# Altium schematic sheet Sheet1.SchDoc
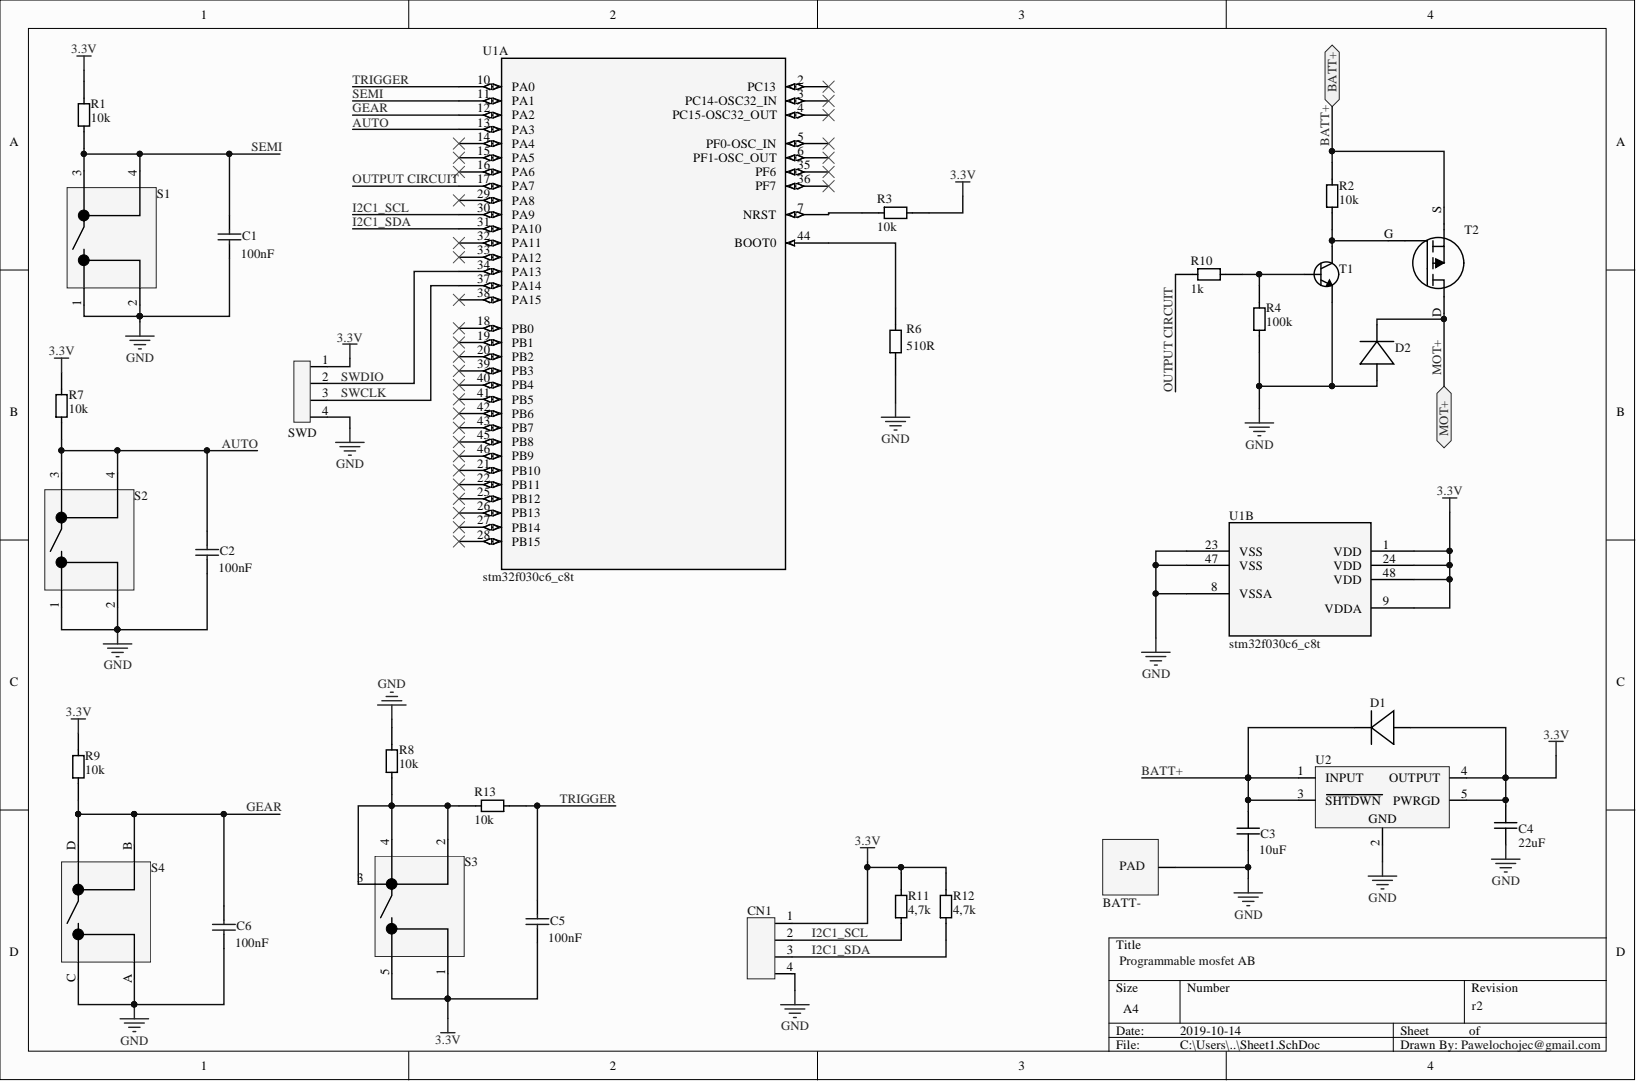
[diagram p0: Sheet1.SchDoc]

## Parts
C1  value 100nF  [C1206]  (hidden comment: Cap Semi)
C2  value 100nF  [C1206]  (hidden comment: Cap Semi)
C3  Capacitance: 10uF  [0805]  (hidden comment: CL21A106KOQNNNE)
C4  Capacitance: 22uF  [0805]  (hidden comment: CL21A226MQQNNNG)
C5  value 100nF  [C1206]  (hidden comment: Cap Semi)
C6  value 100nF  [C1206]  (hidden comment: Cap Semi)
CN1  501568-0407  [Molex 4x1]  (value not drawn)
CN3  BATT-  [PAD_1,5x0,8]
D1  TS4148RYG  [0805 diode]  (value not drawn)
D2  TS4148RYG  [0805 diode]  (value not drawn)
R1  value 10k  [PCBComponent_1]  (hidden comment: Resistor 0805)
R2  value 10k  [PCBComponent_1]  (hidden comment: Resistor 0805)
R3  value 10k  [PCBComponent_1]  (hidden comment: Resistor 0805)
R4  value 100k  [PCBComponent_1]  (hidden comment: Resistor 0805)
R6  value 510R  [PCBComponent_1]  (hidden comment: Resistor 0805)
R7  value 10k  [PCBComponent_1]  (hidden comment: Resistor 0805)
R8  value 10k  [PCBComponent_1]  (hidden comment: Resistor 0805)
R9  value 10k  [PCBComponent_1]  (hidden comment: Resistor 0805)
R10  value 1k  [PCBComponent_1]  (hidden comment: Resistor 0805)
R11  value 4,7k  [PCBComponent_1]  (hidden comment: Resistor 0805)
R12  value 4,7k  [PCBComponent_1]  (hidden comment: Resistor 0805)
R13  value 10k  [PCBComponent_1]  (hidden comment: Resistor 0805)
S1  HDT0004  [HDT0004]  (value not drawn)
S2  HDT0004  [HDT0004]  (value not drawn)
S3  KMS221GPLFS  [KMS221GLFS]  (value not drawn)
S4  HDP001L  [HDP001L]  (value not drawn)
SWD  3x1 pinout 1,27mm  [4x1 pinout 1,27mm]  (value not drawn)
T1  BC817W,115  [BC817W.115]  (value not drawn)
T2  PowerFLAT 5x6 P-CH Transistor  [PowerFLAT 5x6]  (value not drawn)
U1  stm32f030c6_c8t  [LQFP48-7x7mm]  (parts A,B)
U2  MCP1755T-3302E/OT  [SOT 23-5, AP2127K-3.3TRG1]  (value not drawn)

## Ports
BATT+, MOT+

## Nets
3.3V: C4.1 C5.1 CN1.1 D1.A R1.2 R3.2 R7.2 R9.2 R11.2 R12.2 S3.1 S3.5 SWD.1 U1.1(VDD) U1.9(VDDA) U1.24(VDD) U1.48(VDD) U2.4(OUTPUT) U2.5(PWRGD)
GND: C1.1 C2.1 C3.2 C4.2 C6.1 CN1.4 CN3.1 D2.A R4.1 R6.1 R8.2 S1.1 S1.2 S2.1 S2.2 S4.A S4.C SWD.4 T1.2 U1.8(VSSA) U1.23(VSS) U1.47(VSS) U2.2
AUTO: C2.2 R7.1 S2.3 S2.4 U1.13(PA3)
BATT+: C3.1 D1.K R2.2 T2.S U2.1(INPUT) U2.3(SHTDWN) ; port BATT+
GEAR: C6.2 R9.1 S4.B S4.D U1.12(PA2)
I2C1_SCL: CN1.2 R11.1 U1.30(PA9)
I2C1_SDA: CN1.3 R12.1 U1.31(PA10)
MOT+: D2.K T2.D ; port MOT+
OUTPUT CIRCUIT: R10.1 U1.17(PA7)
SEMI: C1.2 R1.1 S1.3 S1.4 U1.11(PA1)
SWCLK: SWD.3 U1.37(PA14)
SWDIO: SWD.2 U1.34(PA13)
TRIGGER: C5.2 R13.1 U1.10(PA0)
NetR2_1: R2.1 T1.3 T2.G
NetR3_1: R3.1 U1.7(NRST)
NetR4_2: R4.2 R10.2 T1.1
NetR6_2: R6.2 U1.44(BOOT0)
NetR8_1: R8.1 R13.2 S3.2 S3.3 S3.4

Not connected: U1 2(PC13) 3(PC14-OSC32_IN) 4(PC15-OSC32_OUT) 5(PF0-OSC_IN) 6(PF1-OSC_OUT) 14(PA4) 15(PA5) 16(PA6) 18(PB0) 19(PB1) 20(PB2) 21(PB10) 22(PB11) 25(PB12) 26(PB13) 27(PB14) 28(PB15) 29(PA8) 32(PA11) 33(PA12) 35(PF6) 36(PF7) 38(PA15) 39(PB3) 40(PB4) 41(PB5) 42(PB6) 43(PB7) 45(PB8) 46(PB9)

## Library data of the parts
C1 C2 C5 C6 = Cap Semi: Capacitor (Semiconductor SIM Model); Component Kind: Standard; LatestRevisionDate: 29-May-2009; LatestRevisionNote: IPC-7351 Footprint Added.; Library Name: Miscellaneous Devices.IntLib; PackageDescription: Chip Capacitor; PackageReference: C1206; Published: 8-Jun-2000; Publisher: Altium Limited; Signal Integrity: Cap Semi; Simulation: CAP
C3 = CL21A106KOQNNNE: Cap Ceramic 10uF 16V X5R 10% SMD 0805 85C T/R; Manufacturer: Samsung; Dielectric: X7R; Case/Package: 0805; Case Code (Imperial): 0805; Case Code (Metric): 2012; Component Kind: Standard; ComponentLink1Description: http://www.samsungsem.com/kr/support/product-search/mlcc/CL21A106KOQNNNE.jsp?src-supplier=Digi-Key; ComponentLink1URL: http://www.samsungsem.com/kr/support/product-search/mlcc/CL21A106KOQNNNE.jsp?src-supplier=Digi-Key; ComponentLink2Description: http://www1.futureelectronics.com/doc/SAMSUNG%20PASSIVE/CL05B104KQ5NNNC.pdf?src-supplier=Future+Electronics; ComponentLink2URL: http://www1.futureelectronics.com/doc/SAMSUNG%20PASSIVE/CL05B104KQ5NNNC.pdf?src-supplier=Future+Electronics; ComponentLink3Description: https://www.verical.com/server-webapp/html/getDatasheet?partID=358435&src-supplier=Verical; ComponentLink3URL: https://www.verical.com/server-webapp/html/getDatasheet?partID=358435&src-supplier=Verical; Depth: 1.25mm; Height: 1.25mm; Lead Free: Lead Free; Length: 2mm; Library Name: mosfet_STM32.SchLib; Manufacturer Part Number: CL21A106KOQNNNE; Max Operating Temperature: 85°C; Min Operating Temperature: -55°C; Mount: Surface Mount; Package Quantity: 1; Packaging: Tape and Reel; Pricing 1: 100=0.02993, 300=0.02117, 1000=0.01541, 2000=0.01308, 10000=0.01253 (USD); Radiation Hardening: No; Stock 1: 80000; Supplier 1: TME; Supplier Part Number 1: CL21A106KOQNNNE; Thickness: 0.055inch; Tolerance: 10%; Voltage: 16V; Voltage Rating: 16V; Voltage Rating (DC): 16V; Width: 0.049inch
C4 = CL21A226MQQNNNG: Cap Ceramic 22uF 6.3V X5R 20% SMD 0805 85C Embossed T/R; Manufacturer: Samsung; Case/Package: 0805; Case Code (Imperial): 0805; Case Code (Metric): 2012; Component Kind: Standard; ComponentLink1Description: http://www.samsungsem.com/kr/support/product-search/mlcc/CL21A226MQQNNNG.jsp?src-supplier=Digi-Key; ComponentLink1URL: http://www.samsungsem.com/kr/support/product-search/mlcc/CL21A226MQQNNNG.jsp?src-supplier=Digi-Key; ComponentLink2Description: https://produktinfo.conrad.com/datenblaetter/450000-474999/454647-da-01-en-VS_KONDENSATOR_0805.pdf?src-supplier=Conrad; ComponentLink2URL: https://produktinfo.conrad.com/datenblaetter/450000-474999/454647-da-01-en-VS_KONDENSATOR_0805.pdf?src-supplier=Conrad; Depth: 1.25mm; Dielectric: X5R; Height: 1.25mm; Length: 2mm; Library Name: mosfet_STM32.SchLib; Manufacturer Part Number: CL21A226MQQNNNG; Material: Ceramic; Max Operating Temperature: 85°C; Min Operating Temperature: -55°C; Mount: Surface Mount; Package Quantity: 3000; Packaging: Tape and Reel; Pricing 1: 100=0.03725, 300=0.0296, 1000=0.02317, 3000=0.01829, 12000=0.0174 (USD); Radiation Hardening: No; Stock 1: 38100; Supplier 1: TME; Supplier Part Number 1: CL21A226MQQNNNG; Thickness: 0.055inch; Tolerance: 20%; Voltage: 6.3V; Voltage Rating: 6.3V; Width: 0.049inch
CN1 = 501568-0407: Conn Shrouded Header HDR 4 POS 1mm Solder RA SMD Pico-Clasp™ T/R; Manufacturer: Molex; Connector Type: Male Pin; Current Rating: 1A; Gender: Male; Glow Wire Compliant: Non-compliant; Max Voltage Rating (AC): 50V; Max Voltage Rating (DC): 5.05kV; Number of Circuits: 4; Color: Natural; Component Kind: Standard; ComponentLink1Description: http://www.molex.com/webdocs/datasheets/pdf/en-us/5015680407_PCB_HEADERS.pdf?src-supplier=Mouser; ComponentLink1URL: http://www.molex.com/webdocs/datasheets/pdf/en-us/5015680407_PCB_HEADERS.pdf?src-supplier=Mouser; ComponentLink2Description: http://www.onlinecomponents.com/productFiles/mf-MOL/940865015680407_pcb_headers.pdf?src-supplier=Onlinecomponents.com; ComponentLink2URL: http://www.onlinecomponents.com/productFiles/mf-MOL/940865015680407_pcb_headers.pdf?src-supplier=Onlinecomponents.com; ComponentLink3Description: http://www.molex.com/webdocs/datasheets/pdf/en-us/5015680407_PCB_HEADERS.pdf?src-supplier=Avnet; ComponentLink3URL: http://www.molex.com/webdocs/datasheets/pdf/en-us/5015680407_PCB_HEADERS.pdf?src-supplier=Avnet; ComponentLink4Description: https://www.molex.com/pdm_docs/ps/PS-501568-001.pdf?src-supplier=Digi-Key; ComponentLink4URL: https://www.molex.com/pdm_docs/ps/PS-501568-001.pdf?src-supplier=Digi-Key; ComponentLink5Description: http://uk.rs-online.com/webdocs/13c5/0900766b813c54a0.pdf?src-supplier=RSComponents; ComponentLink5URL: http://uk.rs-online.com/webdocs/13c5/0900766b813c54a0.pdf?src-supplier=RSComponents; ComponentLink6Description: http://www.molex.com/webdocs/datasheets/pdf/en-us/5015680407_PCB_HEADERS.pdf?src-supplier=Avnet+Europe; ComponentLink6URL: http://www.molex.com/webdocs/datasheets/pdf/en-us/5015680407_PCB_HEADERS.pdf?src-supplier=Avnet+Europe; Contact Material: Bronze,Brass; Contact Plating: Tin; Depth: 5.35mm; Fastening Type: Detent; Housing Color: Natural; Housing Material: Nylon; Lead Free: Lead Free; Length: 6mm; Library Name: mosfet_STM32.SchLib; Manufacturer Part Number: 501568-0407; Max Operating Temperature: 85°C; Min Operating Temperature: -40°C; Mount: Surface Mount; Number of Contacts: 4; Number of Positions: 4; Number of Rows: 1; Orientation: Right Angle; Package Quantity: 1700; Packaging: Tape and Reel; Pitch: 1mm; Pricing 1: 2=0.59, 20=0.53, 100=0.48, 500=0.42 (USD); Radiation Hardening: No; REACH SVHC: Unknown; Stock 1: 1606; Supplier 1: TME; Supplier Part Number 1: MX-501568-0407; Termination: Solder; Voltage Rating: 50V; Voltage Rating (AC): 50V
CN3 = PAD_1,5x0,8: Component Kind: Standard; Library Name: mosfet_STM32.SchLib
D1 D2 = TS4148RYG: Diode Small Signal Switching 75V 0.15A 2-Pin Case 0805 T/R; Manufacturer: Taiwan Semiconductor; Element Configuration: Single; Forward Voltage: 1V; Max Forward Surge Current (Ifsm): 2A; Reverse Recovery Time: 4ns; Case/Package: SMD/SMT; Component Kind: Standard; ComponentLink1Description: http://www.rapidonline.com/pdf/47-1004.pdf?src-supplier=Rapid+Electronics; ComponentLink1URL: http://www.rapidonline.com/pdf/47-1004.pdf?src-supplier=Rapid+Electronics; ComponentLink2Description: https://www.verical.com/server-webapp/html/getDatasheet?partID=461722&src-supplier=Verical; ComponentLink2URL: https://www.verical.com/server-webapp/html/getDatasheet?partID=461722&src-supplier=Verical; Library Name: mosfet_STM32.SchLib; Manufacturer Part Number: TS4148RYG; Max Operating Temperature: 175°C; Max Repetitive Reverse Voltage (Vrrm): 75V; Min Operating Temperature: -65°C; Mount: Surface Mount; Number of Pins: 2; Packaging: Tape and Reel; Peak Non-Repetitive Surge Current: 2A; Pricing 1: 25=0.0275, 100=0.018, 500=0.0144, 1000=0.0129, 5000=0.012 (USD); Radiation Hardening: No; Stock 1: 145631; Supplier 1: TME; Supplier Part Number 1: TS4148-RYG
R1-R4 R6-R13 = 0805S8F1000T5E: Resistor: thick film; SMD; 0805; 100O; 0.125W; ±1%; -55÷125°C; Manufacturer: Royal Electronic Factory; Component Kind: Standard; Library Name: Schlib1.SchLib; Manufacturer Part Number: 0805S8F1000T5E; Pricing 1: 5000=0.00537, 50000=0.00395, 200000=0.0037 (USD); Stock 1: 100000; Supplier 1: TME; Supplier Part Number 1: 0805S8F1000T5E
S1 S2 = HDT0004: SWITCH DETECT MICRO SPST SMD 5V; Manufacturer: ITT C&K; Actuator Length: 1.5mm; Actuator Type: Lever; Contact Current Rating: 1mA; Contact Resistance: 500mR; Electrical Life: 100000Cycles; Operating Force: 0.34N; Operating Position: 0.138inch; Overtravel: 0.039inch; Pretravel: 0.012inch; Switch Function: Off-Mom; Case/Package: SMD/SMT; Component Kind: Standard; ComponentLink1Description: http://www.farnell.com/datasheets/1707717.pdf?src-supplier=Element14; ComponentLink1URL: http://www.farnell.com/datasheets/1707717.pdf?src-supplier=Element14; ComponentLink2Description: http://www.ck-components.com/index.php?module=media&action=Display&cmpref=15147&lang=en&width=&height=&format=&alt=&src-supplier=Digi-Key; ComponentLink2URL: http://www.ck-components.com/index.php?module=media&action=Display&cmpref=15147&lang=en&width=&height=&format=&alt=&src-supplier=Digi-Key; ComponentLink3Description: https://www.ckswitches.com/media/1307/hdt.pdf?src-supplier=Digi-Key; ComponentLink3URL: https://www.ckswitches.com/media/1307/hdt.pdf?src-supplier=Digi-Key; Contact Material: Bronze,Silver; Current Rating: 1mA; Height: 2.3mm; Lead Free: Lead Free; Library Name: mosfet_STM32.SchLib; Manufacturer Part Number: HDT0004; Max Operating Temperature: 85°C; Max Voltage Rating (DC): 5V; Mechanical Life: 100000Cycles; Min Operating Temperature: -40°C; Mount: Surface Mount; Number of Terminals: 4; Orientation: Straight; Package Quantity: 1; Packaging: Tape and Reel; Pricing 1: 1=0.9131, 5=0.8114, 20=0.7611, 100=0.7108, 500=0.6851 (USD); REACH SVHC: No SVHC; Stock 1: 2654; Supplier 1: TME; Supplier Part Number 1: HDT0004; Termination: SMD/SMT; Throw Configuration: SPST; Voltage Rating (DC): 5V; Width: 3.8mm
S3 = KMS221GPLFS: SWITCH TACTILE SPST-NO 0.05A 50V; Manufacturer: ITT C&K; Actuator Length: 0.75mm; Contact Current Rating: 50mA; Illumination Type: Non-Illuminated; Max Voltage Rating (DC): 32V; Mechanical Life: 100000Cycles; Operating Force: 2N; Power Rating: 1W; Ratings: IP40; Switch Function: Off-Mom; Switch Travel: 0.25mm; Throw Configuration: SPST; Component Kind: Standard; ComponentLink1Description: http://www.ck-components.com/index.php?module=media&action=Display&cmpref=14416&lang=en&width=&height=&format=&alt=&src-supplier=Digi-Key; ComponentLink1URL: http://www.ck-components.com/index.php?module=media&action=Display&cmpref=14416&lang=en&width=&height=&format=&alt=&src-supplier=Digi-Key; ComponentLink2Description: http://www.ck-components.com/14416/kms_31aug10.pdf/?src-supplier=Mouser; ComponentLink2URL: http://www.ck-components.com/14416/kms_31aug10.pdf/?src-supplier=Mouser; ComponentLink3Description: https://www.ckswitches.com/media/1482/kms.pdf?src-supplier=Digi-Key; ComponentLink3URL: https://www.ckswitches.com/media/1482/kms.pdf?src-supplier=Digi-Key; Contact Material: Silver; Contact Plating: Silver; Current Rating: 50mA; Depth: 4.6mm; Lead Free: Lead Free; Length: 3.55mm; Library Name: mosfet_STM32.SchLib; Manufacturer Part Number: KMS221GPLFS; Max Current Rating: 50mA; Max Operating Temperature: 85°C; Min Operating Temperature: -40°C; Mount: Surface Mount; Orientation: Right Angle; Package Quantity: 1; Packaging: Tape and Reel; Radiation Hardening: No; Stock 1: 0; Supplier 1: TME; Supplier Part Number 1: KMS221GPLFS; Termination: Gull Wing; Voltage Rating (DC): 32V; Width: 1.42mm
T1 = BC817W,115: TRANS NPN 45V 0.5A SOT323; Manufacturer: Nexperia USA; Component Kind: Standard; Library Name: mosfet_STM32.SchLib; Manufacturer Part Number: BC817W,115; Stock 1: 0; Supplier 1: Farnell; Supplier Part Number 1: 2439014
T2 = STL42P6LLF6: MOSFET P-CH 60V 42A 8POWERFLAT; Manufacturer: STMicroelectronics; Drain to Source Resistance: 34mR; Element Configuration: Single; Gate to Source Voltage (Vgs): 20V; Input Capacitance: 3.78nF; Max Power Dissipation: 100W; Rds On Max: 26mR; Turn-Off Delay Time: 171ns; Turn-On Delay Time: 51.4ns; Component Kind: Standard; Continuous Drain Current (ID): 42A; Drain to Source Voltage (Vdss): 60V; Height: 0.95mm; Lead Free: Lead Free; Length: 6.35mm; Library Name: Schlib1.SchLib; Manufacturer Part Number: STL42P6LLF6; Max Operating Temperature: 175°C; Mount: Surface Mount; Number of Pins: 8; Packaging: Tape and Reel; Pricing 1: 1=1.13, 5=1.02, 25=0.89, 100=0.8 (USD); Stock 1: 0; Supplier 1: TME; Supplier Part Number 1: STL42P6LLF6; Width: 5.4mm
U1 = STM32F030C6_C8T: No Description Available; Vendor: STMicroelectronics; Component Kind: Standard; Copyright: Copyright (C) 2016 Accelerated Designs. All rights reserved; Library Name: STM32F030C8T6.SchLib; Manufacturer_Part_Number: stm32f030c6_c8t; RefDes: RefDes; Type: DEV; Value: Value
U2 = MCP1755T-3302E/OT: MICROCHIP   MCP1755T-3302E/OT   IC, LDO VOLT REG, 3.3V, 0.3A, SOT23-5; Accuracy: 2%; Dropout Voltage: 300mV; Max Input Voltage: 16V; Max Output Current: 300mA; Min Input Voltage: 3.6V; Nominal Supply Current: 68uA; Number of Outputs: 1; Output Type: Fixed; Polarity: Positive; Power Supply Rejection Ratio (PSRR): 80dB; Quiescent Current: 100uA; Case/Package: SOT-23-5; Component Kind: Standard; ComponentLink1Description: http://www.microchip.com/mymicrochip/filehandler.aspx?ddocname=en533805&src-supplier=Digi-Key; ComponentLink1URL: http://www.microchip.com/mymicrochip/filehandler.aspx?ddocname=en533805&src-supplier=Digi-Key; ComponentLink2Description: http://www.microchip.com/mymicrochip/filehandler.aspx?ddocname=en560605&src-supplier=Digi-Key; ComponentLink2URL: http://www.microchip.com/mymicrochip/filehandler.aspx?ddocname=en560605&src-supplier=Digi-Key; ComponentLink3Description: http://www.farnell.com/datasheets/1697992.pdf?src-supplier=Element14; ComponentLink3URL: http://www.farnell.com/datasheets/1697992.pdf?src-supplier=Element14; ComponentLink4Description: https://www.verical.com/server-webapp/html/getDatasheet?partID=775879&src-supplier=Verical; ComponentLink4URL: https://www.verical.com/server-webapp/html/getDatasheet?partID=775879&src-supplier=Verical; Lead Free: Lead Free; Library Name: mosfet_STM32.SchLib; Manufacturer: Microchip; Manufacturer Part Number: MCP1755T-3302E/OT; Max Operating Temperature: 125°C; Min Operating Temperature: -40°C; Mount: Surface Mount; Nominal Output Voltage: 3.3V; Number of Pins: 5; Number of Regulators: 1; Output Current: 300mA; Output Voltage: 3.3V; Output Voltage Accuracy: 2%; Package Quantity: 3000; Packaging: Tape and Reel; Pricing 1: 3=0.75, 10=0.73, 25=0.62, 100=0.57 (USD); Radiation Hardening: No; REACH SVHC: No SVHC; Stock 1: 13; Supplier 1: TME; Supplier Part Number 1: MCP1755T-3302E/OT; Tolerance: 2%
S4 = HDP001L: Component Kind: Standard; Library Name: mosfet_STM32.SchLib
SWD = 3x1 pinout 1,27mm: Component Kind: Standard; Library Name: mosfet_STM32.SchLib
